# Altium schematic sheet MASTER_V1.SchDoc
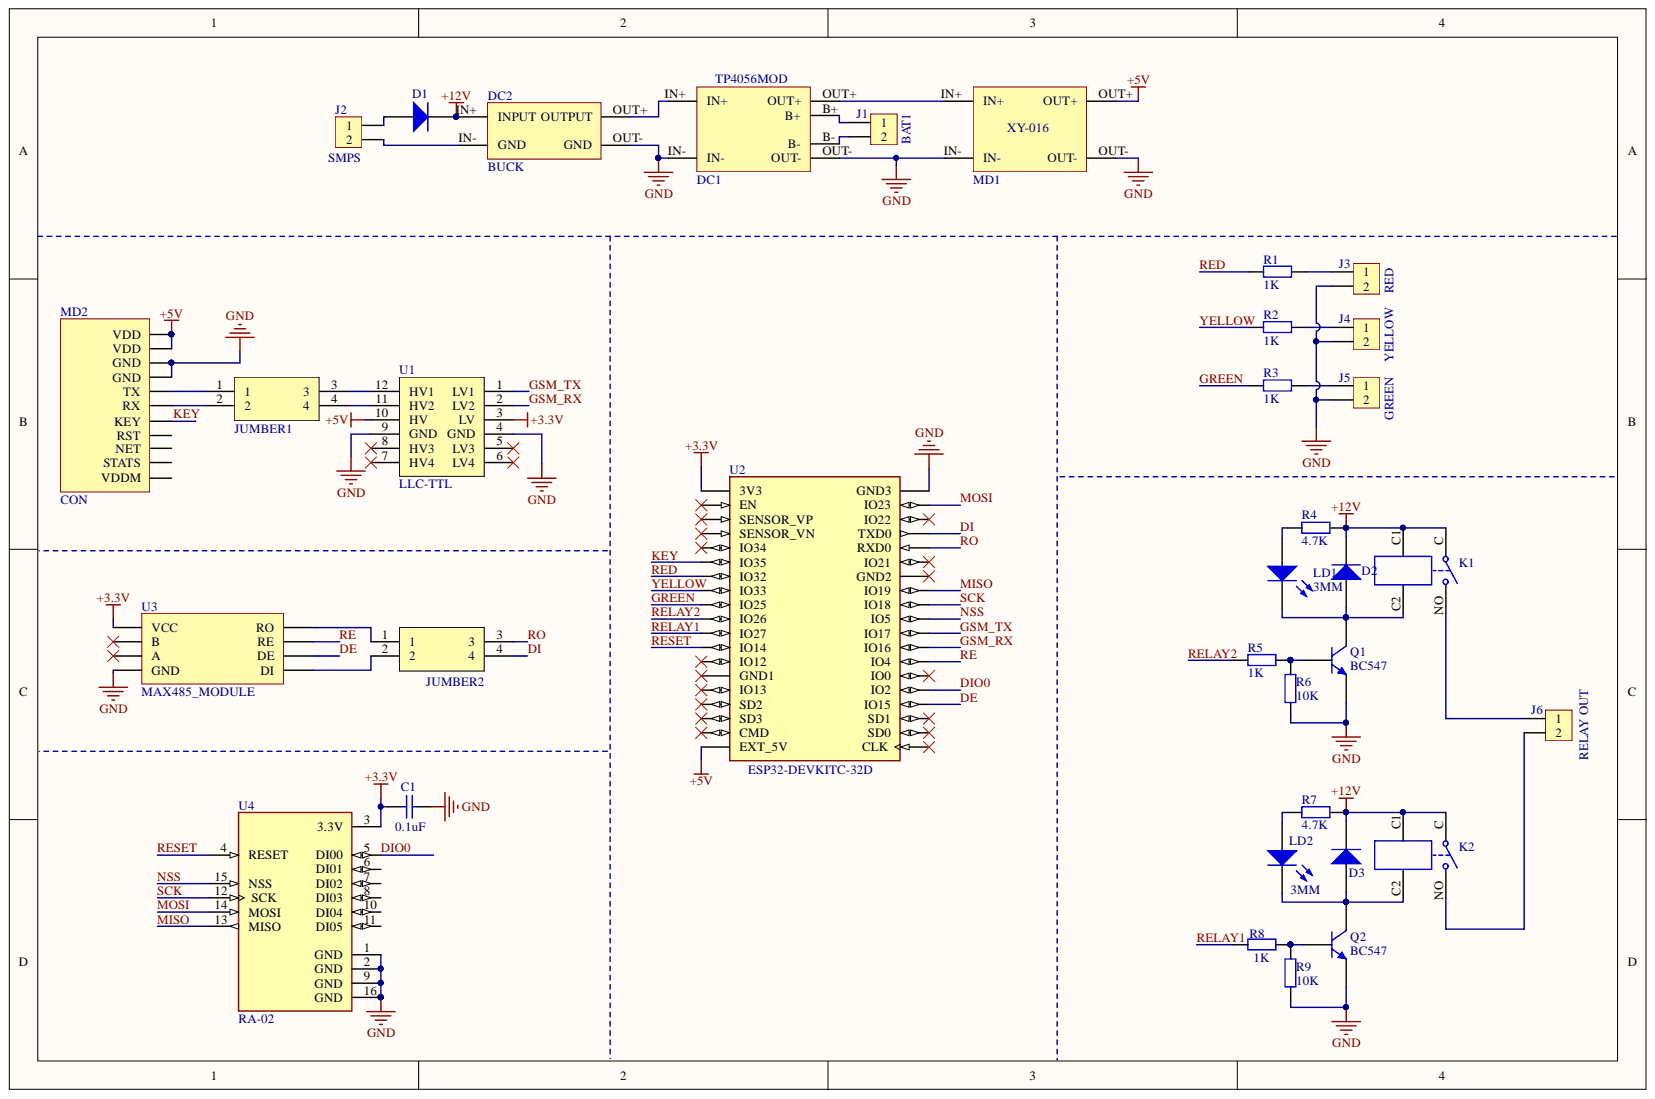
[diagram p0: MASTER_V1.SchDoc]

## Parts
C1  value 0.1uF  [C1206]  (hidden comment: Cap)
D1  Diode  [D10]  (value not drawn)
D2  1N4007  [DO-214AC]  (value not drawn)
D3  1N4007  [DO-214AC]  (value not drawn)
DC1  TP4056MOD  [TP4056MOD]
DC2  BUCK  [BUCK]
J1  BAT1  [JST_2.5MM_2]
J2  PackageReference: SMPS  [PH2]  (hidden comment: 5.08mm)
J3  RED  [JST_2.5MM_2]
J4  YELLOW  [JST_2.5MM_2]
J5  GREEN  [JST_2.5MM_2]
J6  RELAY OUT  [PH2]
JUMBER1  IC4  [IDC-4-H]  (value not drawn)
JUMBER2  IC4  [IDC-4-H]  (value not drawn)
K1  Relay-SPST  [G5NB]  (value not drawn)
K2  Relay-SPST  [G5NB]  (value not drawn)
LD1  3MM  [LED3MM]
LD2  3MM  [LED3MM]
MD1  XY-016  [XY-016]
MD2  CON  [EC200U-CN]
Q1  BC547  [TO-92 - CBE]
Q2  BC547  [TO-92 - CBE]
R1  value 1K  [R1206]  (hidden comment: Res2)
R2  value 1K  [R1206]  (hidden comment: Res2)
R3  value 1K  [R1206]  (hidden comment: Res2)
R4  value 4.7K  [R1206]  (hidden comment: Res2)
R5  value 1K  [R1206]  (hidden comment: Res2)
R6  value 10K  [R1206]  (hidden comment: Res2)
R7  value 4.7K  [R1206]  (hidden comment: Res2)
R8  value 1K  [R1206]  (hidden comment: Res2)
R9  value 10K  [R1206]  (hidden comment: Res2)
U1  LLC-TTL  [LLC-TTL]
U2  ESP32-DEVKITC-32D  [ESP32-DEVKITC-32D]
U3  MAX485_MODULE  [RS485]
U4  RA-02  [LORACHIP]

## Nets
+3.3V: C1.1 U1.3(LV) U2.J2-1(3V3) U3.VCC U4.3(3.3V)
+5V: MD1.OUT+ MD2.1(VDD) MD2.2(VDD) U1.10(HV) U2.J2-19(EXT_5V)
+12V: D1.2 D2.2 D3.2 DC2.IN+(INPUT) K1.C K1.C1 K2.C K2.C1 R4.1 R7.1
GND: C1.2 DC1.IN- DC1.OUT- DC2.OUT- J3.2 J4.2 J5.2 MD1.IN- MD1.OUT- MD2.3(GND) MD2.4(GND) Q1.3 Q2.3 R6.1 R9.1 U1.4(GND) U1.9(GND) U2.J3-1(GND3) U3.GND U4.1(GND) U4.2(GND) U4.9(GND) U4.16(GND)
DE: U2.J3-16(IO15) U3.DE
DI: JUMBER2.4 U2.J3-4(TXD0)
DIO0: U2.J3-15(IO2) U4.5(DI00)
GREEN: R3.1 U2.J2-9(IO25)
GSM_RX: U1.2(LV2) U2.J3-12(IO16)
GSM_TX: U1.1(LV1) U2.J3-11(IO17)
KEY: MD2.7(KEY) U2.J2-6(IO35)
MISO: U2.J3-8(IO19) U4.13(MISO)
MOSI: U2.J3-2(IO23) U4.14(MOSI)
NSS: U2.J3-10(IO5) U4.15(NSS)
RE: U2.J3-13(IO4) U3.RE
RED: R1.1 U2.J2-7(IO32)
RELAY1: R8.1 U2.J2-11(IO27)
RELAY2: R5.1 U2.J2-10(IO26)
RESET: U2.J2-12(IO14) U4.4(RESET)
RO: JUMBER2.3 U2.J3-5(RXD0)
SCK: U2.J3-9(IO18) U4.12(SCK)
YELLOW: R2.1 U2.J2-8(IO33)
NetD1_1: D1.1 J2.1
NetD2_1: D2.1 K1.C2 LD1.2 Q1.1
NetD3_1: D3.1 K2.C2 LD2.2 Q2.1
NetDC1_B+: DC1.B+ J1.1
NetDC1_B-: DC1.B- J1.2
NetDC1_IN+: DC1.IN+ DC2.OUT+(OUTPUT)
NetDC1_OUT+: DC1.OUT+ MD1.IN+
NetDC2_IN-: DC2.IN- J2.2
NetJ3_1: J3.1 R1.2
NetJ4_1: J4.1 R2.2
NetJ5_1: J5.1 R3.2
NetJ6_1: J6.1 K1.NO
NetJ6_2: J6.2 K2.NO
NetJUMBER1_1: JUMBER1.1 MD2.5(TX)
NetJUMBER1_2: JUMBER1.2 MD2.6(RX)
NetJUMBER1_3: JUMBER1.3 U1.12(HV1)
NetJUMBER1_4: JUMBER1.4 U1.11(HV2)
NetJUMBER2_1: JUMBER2.1 U3.RO
NetJUMBER2_2: JUMBER2.2 U3.DI
NetLD1_1: LD1.1 R4.2
NetLD2_1: LD2.1 R7.2
NetQ1_2: Q1.2 R5.2 R6.2
NetQ2_2: Q2.2 R8.2 R9.2

Not connected: MD2 8(RST) 9(NET) 10(STATS) 11(VDDM); U1 5(LV3) 6(LV4) 7(HV4) 8(HV3); U2 J2-2(EN) J2-3(SENSOR_VP) J2-4(SENSOR_VN) J2-5(IO34) J2-13(IO12) J2-14(GND1) J2-15(IO13) J2-16(SD2) J2-17(SD3) J2-18(CMD) J3-3(IO22) J3-6(IO21) J3-7(GND2) J3-14(IO0) J3-17(SD1) J3-18(SD0) J3-19(CLK); U3 A B; U4 6(DI01) 7(DI02) 8(DI03) 10(DI04) 11(DI05)

## Library data of the parts
C1 = Cap: Capacitor; Component Kind: Standard; LatestRevisionDate: 17-Jul-2002; LatestRevisionNote: Re-released for DXP Platform.; Library Name: Component Devices.SchLib; PackageDescription: Radial Cap, Thru-Hole; 2 Leads; 0.3 in Pin Spacing; PackageReference: RAD-0.3; Published: 8-Jun-2000; Simulation: CAP; Publisher: Altium Limited
D1-D3 = Diode: Default Diode; Code_JEDEC: DO-214-AB; Component Kind: Standard; LatestRevisionDate: 17-Jul-2002; LatestRevisionNote: Re-released for DXP Platform.; Library Name: Component Devices.SchLib; PackageDescription: DO-214-AB/SMC; 2 C-Bend Leads; Body 7.9 x 5.9 mm, inc. leads (LxW); PackageVersion: Sep-1996; Published: 8-Jun-2000; Simulation: DIODE; Publisher: Altium Limited
  D1: PackageReference: SMC
  D2 D3: PackageReference: 1206
DC1 = TP4056MOD: DeviceSetName: TP4056; GateName_1: G$1; Component Kind: Standard; Library Name: Component Devices.SchLib; SymbolName_1: TP4056; LibraryName: 32teeth - TP4056 Module
J1-J6 = H2: Component Kind: Standard; Library Name: Component Devices.SchLib
  J1 J3-J6: PackageReference: SRW TER-ST
JUMBER1 JUMBER2 = IC4: ANY 8PIN; Component Kind: Standard; Library Name: Component Devices.SchLib
K1 K2 = Relay-SPST: Single-Pole Single-Throw Relay; Component Kind: Standard; LatestRevisionDate: 17-Jul-2002; LatestRevisionNote: Re-released for DXP Platform.; Library Name: Component Devices.SchLib; PackageReference: MODULE4; Published: 24-Mar-1999; Simulation: SPSTRELAY; Publisher: Altium Limited
LD1 LD2 = LED0: Typical INFRARED GaAs LED; Component Kind: Standard; LatestRevisionDate: 08-Jul-2005; LatestRevisionNote: Mech Layer 1 removed.; Library Name: Component Devices.SchLib; PackageDescription: LED; 2 Leads; PackageReference: LED-0; Published: 8-Jun-2000; Simulation: LED0; Publisher: Altium Limited
MD1 = XY-016: DeviceSetName: TP4056; GateName_1: G$1; Component Kind: Standard; Library Name: Component Devices.SchLib; SymbolName_1: TP4056; LibraryName: 32teeth - TP4056 Module
MD2 = EC200U: Component Kind: Standard; Library Name: Component Devices.SchLib
Q1 Q2 = NPN: NPN Bipolar Transistor; Code_JEDEC: TO-226-AA; Component Kind: Standard; LatestRevisionDate: 17-Jul-2002; LatestRevisionNote: Re-released for DXP Platform.; Library Name: Component Devices.SchLib; PackageDescription: TO, Flat Index; 3 In-Line, Axial Leads; Body Dia. 4.8mm; Leads 0.55 x 0.51 mm (max); PackageReference: TO-226-AA; Published: 8-Jun-2000; Simulation: NPN; Publisher: Altium Limited
R1-R9 = Res2: Resistor; Component Kind: Standard; LatestRevisionDate: 17-Jul-2002; LatestRevisionNote: Re-released for DXP Platform.; Library Name: Component Devices.SchLib; PackageDescription: Axial Device, Thru-Hole; 2 Leads; 0.4 in Pin Spacing; PackageReference: AXIAL-0.4; Published: 8-Jun-2000; Simulation: RESISTOR; Publisher: Altium Limited
U1 = IC12: ANY 14PIN; Component Kind: Standard; Library Name: Component Devices.SchLib
U2 = ESP32-DEVKITC-32D: WiFi Development Tools (802.11) ESP32 General Development Kit, ESP32-WROOM-32D on the board; MF: Espressif Systems; Check_prices: https://www.snapeda.com/parts/ESP32-DEVKITC-32D/Espressif+Systems/view-part/?ref=eda; Component Kind: Standard; Library Name: Component Devices.SchLib; MP: ESP32-DEVKITC-32D; Package: None; SnapEDA_Link: https://www.snapeda.com/parts/ESP32-DEVKITC-32D/Espressif+Systems/view-part/?ref=snap
U4 = RA-02: General ISM < 1GHz LoRaâ„¢ Transceiver Module 410MHz ~ 525MHz Antenna Not Included, I-PEX Surface Mount; MF: Ai-Thinker; Availability: Not in stock; Check_prices: https://www.snapeda.com/parts/RA-02/AI-Thinker/view-part/?ref=eda; Component Kind: Standard; Library Name: Component Devices.SchLib; MP: RA-02; Package: None; Price: None; SnapEDA_Link: https://www.snapeda.com/parts/RA-02/AI-Thinker/view-part/?ref=snap
DC2 = BUCK: Component Kind: Standard; Library Name: Component Devices.SchLib
U3 = MAX485_MODULE: Component Kind: Standard; Library Name: Component Devices.SchLib
